# Altium schematic sheet Sheet1.SchDoc(Sheet1)
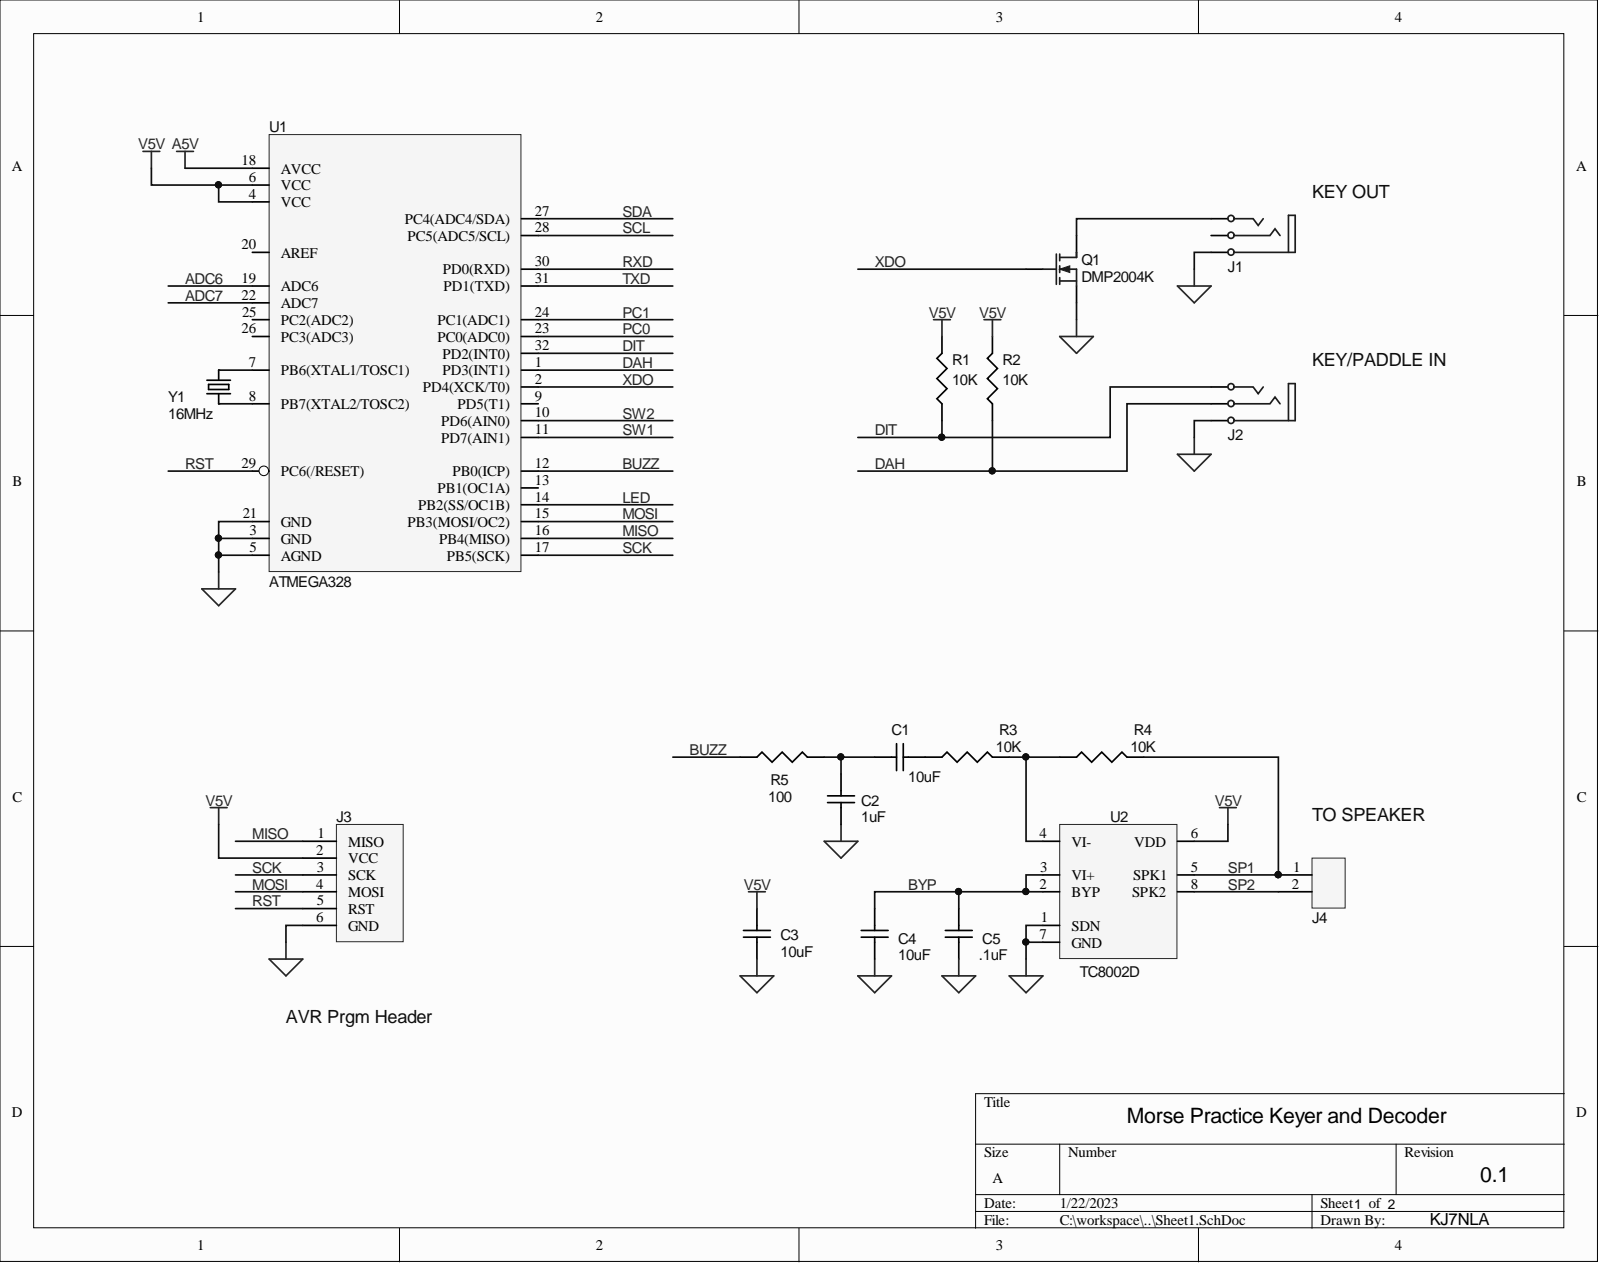
[diagram p0: Sheet1.SchDoc]

## Parts
C1  10uF  [3-0603]
C2  1uF  [3-0603]
C3  10uF  [3-0603]
C4  10uF  [3-0603]
C5  .1uF  [3-0603]
J1  Stereo Jack  [PJ-320B]  (value not drawn)
J2  Stereo Jack  [PJ-320B]  (value not drawn)
J3  Header AVR  [CONN2X3]  (value not drawn)
J4  Header-2  [CONN1X2]  (value not drawn)
Q1  DMP2004K  [SOT23]
R1  10K  [3-0603]
R2  10K  [3-0603]
R3  10K  [3-0603]
R4  10K  [3-0603]
R5  100  [3-0603]
U1  ATMEGA328  [ATMEG328]
U2  TC8002D  [SOP-8]
Y1  16MHz  [Xtal_SMT]

## Nets
A5V: U1.18(AVCC)
GND: C2.1 C3.1 C4.1 C5.1 J1.1 J2.1 J3.6(GND) Q1.2 U1.3(GND) U1.5(AGND) U1.21(GND) U2.1(SDN) U2.7(GND)
V5V: C3.2 J3.2(VCC) R1.2 R2.2 U1.4(VCC) U1.6(VCC) U2.6(VDD)
ADC6: U1.19(ADC6)
ADC7: U1.22(ADC7)
BUZZ: R5.1 U1.12(PB0(ICP))
BYP: C4.2 C5.2 U2.2(BYP) U2.3(VI+)
DAH: J2.2 R2.1 U1.1(PD3(INT1))
DIT: J2.3 R1.1 U1.32(PD2(INT0))
LED: U1.14(PB2(SS/OC1B))
MISO: J3.1(MISO) U1.16(PB4(MISO))
MOSI: J3.4(MOSI) U1.15(PB3(MOSI/OC2))
PC0: U1.23(PC0(ADC0))
PC1: U1.24(PC1(ADC1))
RST: J3.5(RST) U1.29(PC6(/RESET))
RXD: U1.30(PD0(RXD))
SCK: J3.3(SCK) U1.17(PB5(SCK))
SCL: U1.28(PC5(ADC5/SCL))
SDA: U1.27(PC4(ADC4/SDA))
SP1: J4.1 R4.2 U2.5(SPK1)
SP2: J4.2 U2.8(SPK2)
SW1: U1.11(PD7(AIN1))
SW2: U1.10(PD6(AIN0))
TXD: U1.31(PD1(TXD))
XDO: Q1.1 U1.2(PD4(XCK/T0))
NetC1_1: C1.1 C2.2 R5.2
NetC1_2: C1.2 R3.1
NetJ1_3: J1.3 Q1.3
NetR3_2: R3.2 R4.1 U2.4(VI-)
NetU1_7: U1.7(PB6(XTAL1/TOSC1)) Y1.3
NetU1_8: U1.8(PB7(XTAL2/TOSC2)) Y1.1

Not connected: J1 2; U1 9(PD5(T1)) 13(PB1(OC1A)) 20(AREF) 25(PC2(ADC2)) 26(PC3(ADC3))

## Library data of the parts
C1-C5 = Cap: Supplier Part Number 1: NA; Supplier 1: Digi-Key; Component Kind: Standard; Library Name: usdx.SchLib
  C1 C3 C4: Value: 10uF; JLCC Part Number: C344022
  C2: Value: 1uF; JLCC Part Number: C5673
  C5: Value: .1uF; JLCC Part Number: C113803
J1 J2 = C18594: Supplier Part Number 1: NA; Supplier 1: Digi-Key; JLCC Part Number: C18594; Component Kind: Standard; Library Name: usdx.SchLib
Q1 = MOSFET-N: Supplier Part Number 1: NA; Supplier 1: Digi-Key; JLCC Part Number: C8548; Component Kind: Standard; Library Name: usdx.SchLib
R1-R5 = Resistor: Supplier Part Number 1: NA; Supplier 1: Digi-Key; Component Kind: Standard; Library Name: usdx.SchLib
  R1-R4: Value: 10K; JLCC Part Number: C99198
  R5: Value: 100; JLCC Part Number: C22775
U1 = ATMEGA328-SMT: Supplier Part Number 1: NA; Supplier 1: Digi-Key; JLCC Part Number: C14877; Component Kind: Standard; Library Name: usdx.SchLib
U2 = SSM2211: Supplier Part Number 1: NA; Supplier 1: Digi-Key; JLCC Part Number: C87265; Component Kind: Standard; Library Name: usdx.SchLib
Y1 = XTAL-SMT: Value: 16MHz; Supplier Part Number 1: NA; Supplier 1: Digi-Key; JLCC Part Number: C13738; Component Kind: Standard; Library Name: usdx.SchLib
J3 = Header AVR: Supplier Part Number 1: NA; Supplier 1: Digi-Key; JLCC Part Number: NA; Component Kind: Standard; Library Name: usdx.SchLib
J4 = Header-2: Supplier Part Number 1: NA; Supplier 1: NA; JLCC Part Number: NA; Component Kind: Standard; Library Name: usdx.SchLib
